# Altium schematic sheet [7]-FPGA-Power.SchDoc
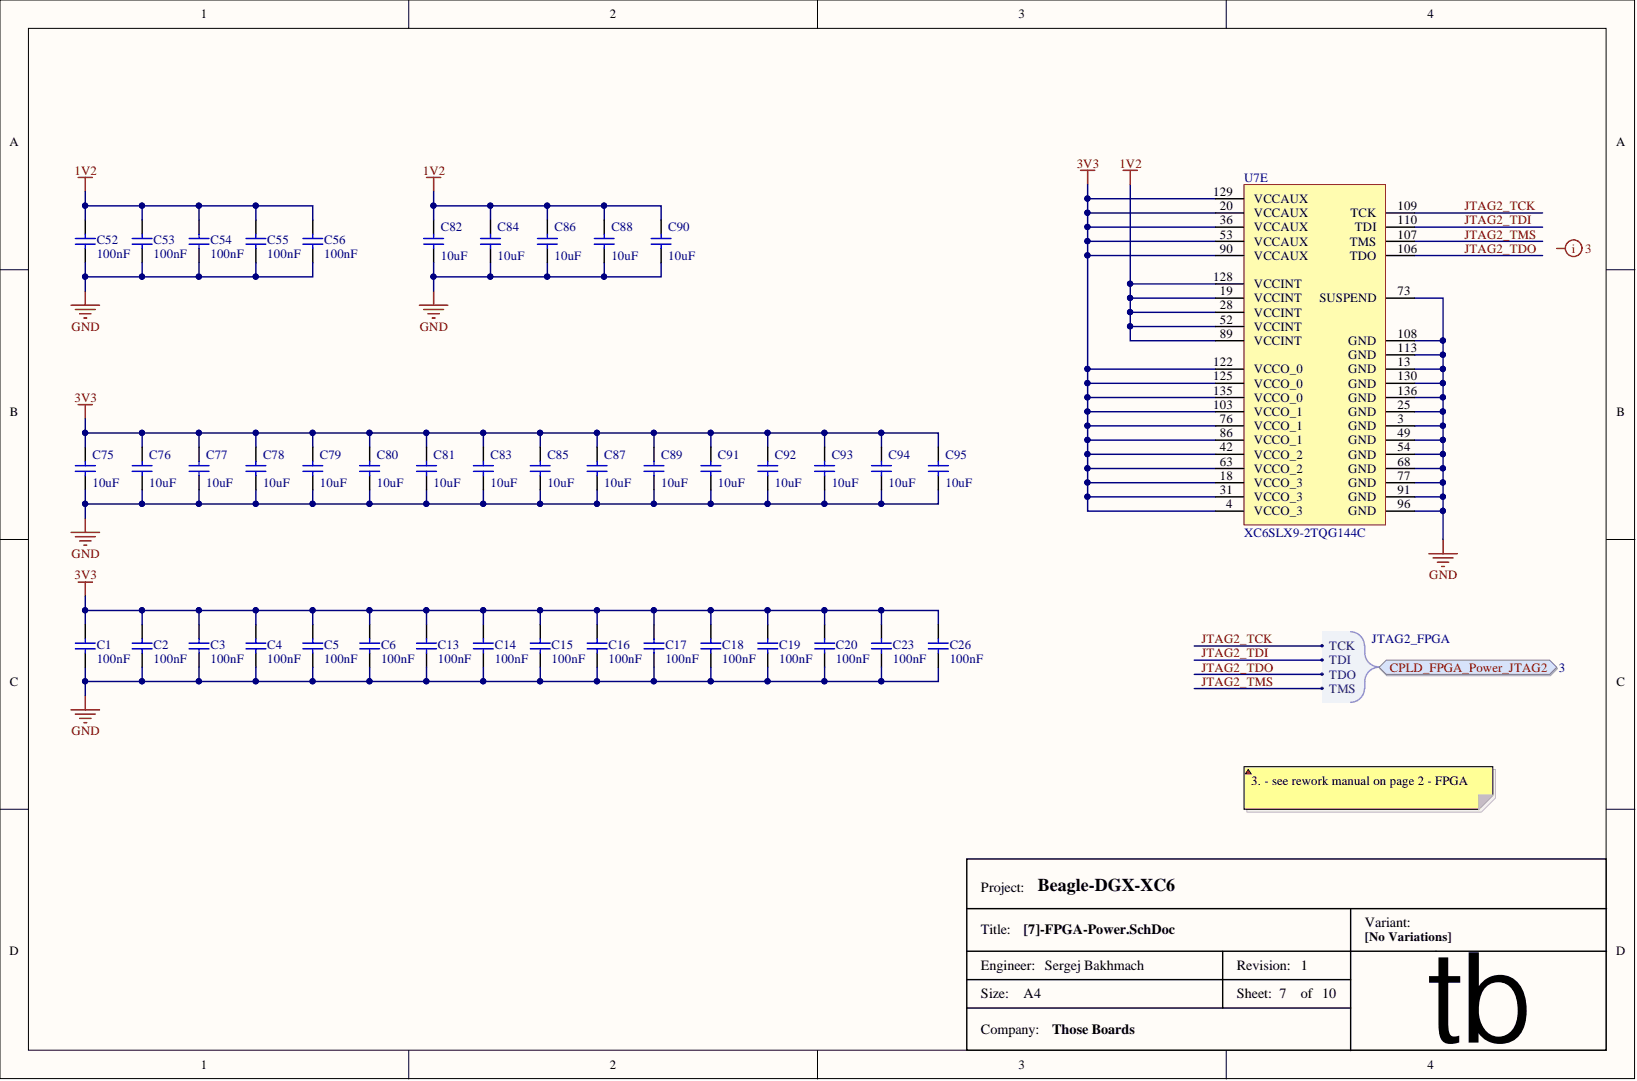
[diagram p0: [7]-FPGA-Power.SchDoc]

## Parts
C1  value 100nF  [C0603]  (hidden comment: CAP)
C2  value 100nF  [C0603]  (hidden comment: CAP)
C3  value 100nF  [C0603]  (hidden comment: CAP)
C4  value 100nF  [C0603]  (hidden comment: CAP)
C5  value 100nF  [C0603]  (hidden comment: CAP)
C6  value 100nF  [C0603]  (hidden comment: CAP)
C13  value 100nF  [C0603]  (hidden comment: CAP)
C14  value 100nF  [C0603]  (hidden comment: CAP)
C15  value 100nF  [C0603]  (hidden comment: CAP)
C16  value 100nF  [C0603]  (hidden comment: CAP)
C17  value 100nF  [C0603]  (hidden comment: CAP)
C18  value 100nF  [C0603]  (hidden comment: CAP)
C19  value 100nF  [C0603]  (hidden comment: CAP)
C20  value 100nF  [C0603]  (hidden comment: CAP)
C23  value 100nF  [C0603]  (hidden comment: CAP)
C26  value 100nF  [C0603]  (hidden comment: CAP)
C52  value 100nF  [C0603]  (hidden comment: CAP)
C53  value 100nF  [C0603]  (hidden comment: CAP)
C54  value 100nF  [C0603]  (hidden comment: CAP)
C55  value 100nF  [C0603]  (hidden comment: CAP)
C56  value 100nF  [C0603]  (hidden comment: CAP)
C75  value 10uF  [C0805]  (hidden comment: CAP)
C76  value 10uF  [C0805]  (hidden comment: CAP)
C77  value 10uF  [C0805]  (hidden comment: CAP)
C78  value 10uF  [C0805]  (hidden comment: CAP)
C79  value 10uF  [C0805]  (hidden comment: CAP)
C80  value 10uF  [C0805]  (hidden comment: CAP)
C81  value 10uF  [C0805]  (hidden comment: CAP)
C82  value 10uF  [C0805]  (hidden comment: CAP)
C83  value 10uF  [C0805]  (hidden comment: CAP)
C84  value 10uF  [C0805]  (hidden comment: CAP)
C85  value 10uF  [C0805]  (hidden comment: CAP)
C86  value 10uF  [C0805]  (hidden comment: CAP)
C87  value 10uF  [C0805]  (hidden comment: CAP)
C88  value 10uF  [C0805]  (hidden comment: CAP)
C89  value 10uF  [C0805]  (hidden comment: CAP)
C90  value 10uF  [C0805]  (hidden comment: CAP)
C91  value 10uF  [C0805]  (hidden comment: CAP)
C92  value 10uF  [C0805]  (hidden comment: CAP)
C93  value 10uF  [C0805]  (hidden comment: CAP)
C94  value 10uF  [C0805]  (hidden comment: CAP)
C95  value 10uF  [C0805]  (hidden comment: CAP)
U7  XC6SLX9-2TQG144C  [TQ144_N_Spartan6]  (part E)

## Ports
CPLD_FPGA_Power_JTAG2, CPLD_FPGA_Power_JTAG2.TCK, CPLD_FPGA_Power_JTAG2.TDI, CPLD_FPGA_Power_JTAG2.TDO, CPLD_FPGA_Power_JTAG2.TMS

## Nets
1V2: C52.2 C53.2 C54.2 C55.2 C56.2 C82.2 C84.2 C86.2 C88.2 C90.2 U7.19(VCCINT) U7.28(VCCINT) U7.52(VCCINT) U7.89(VCCINT) U7.128(VCCINT)
3V3: C1.2 C2.2 C3.2 C4.2 C5.2 C6.2 C13.2 C14.2 C15.2 C16.2 C17.2 C18.2 C19.2 C20.2 C23.2 C26.2 C75.2 C76.2 C77.2 C78.2 C79.2 C80.2 C81.2 C83.2 C85.2 C87.2 C89.2 C91.2 C92.2 C93.2 C94.2 C95.2 U7.4(VCCO_3) U7.18(VCCO_3) U7.20(VCCAUX) U7.31(VCCO_3) U7.36(VCCAUX) U7.42(VCCO_2) U7.53(VCCAUX) U7.63(VCCO_2) U7.76(VCCO_1) U7.86(VCCO_1) U7.90(VCCAUX) U7.103(VCCO_1) U7.122(VCCO_0) U7.125(VCCO_0) U7.129(VCCAUX) U7.135(VCCO_0)
GND: C1.1 C2.1 C3.1 C4.1 C5.1 C6.1 C13.1 C14.1 C15.1 C16.1 C17.1 C18.1 C19.1 C20.1 C23.1 C26.1 C52.1 C53.1 C54.1 C55.1 C56.1 C75.1 C76.1 C77.1 C78.1 C79.1 C80.1 C81.1 C82.1 C83.1 C84.1 C85.1 C86.1 C87.1 C88.1 C89.1 C90.1 C91.1 C92.1 C93.1 C94.1 C95.1 U7.3(GND) U7.13(GND) U7.25(GND) U7.49(GND) U7.54(GND) U7.68(GND) U7.73(SUSPEND) U7.77(GND) U7.91(GND) U7.96(GND) U7.108(GND) U7.113(GND) U7.130(GND) U7.136(GND)
JTAG2_TCK: U7.109(TCK) ; port CPLD_FPGA_Power_JTAG2
JTAG2_TDI: U7.110(TDI) ; port CPLD_FPGA_Power_JTAG2
JTAG2_TDO: U7.106(TDO) ; port CPLD_FPGA_Power_JTAG2
JTAG2_TMS: U7.107(TMS) ; port CPLD_FPGA_Power_JTAG2
N00442:

## Library data of the parts
C1-C6 C13-C20 C23 C26 C52-C56 = CAP: Manufacturer: Vishay / Vitramon; Manufacturer Part: VJ0603Y104JXJPW1BC; Type of Component: Ceramic Capacitor; Component Kind: Standard; Manufacturer Link: http://www.vishay.com/product?docid=28548; Package / Case: 0603; Supplier: mouser; Supplier Link: http://www.mouser.com/ProductDetail/Vishay/VJ0603Y104JXJPW1BC/?qs=%2fha2pyFaduidGoO0X7aK7Xgs%2fjxfhnLiK6SRw4Lz0%252bZK3PJ8DddTrQ%3d%3d; Supplier Part: 77-VJ0603Y104JXJPBC; Library Name: RPI-VITA.IntLib
C75-C95 = CAP: Manufacturer: Murata; Manufacturer Part: GRM21BC81C106KE15L; Type of Component: Ceramic Capacitor; Component Kind: Standard; Manufacturer Link: http://psearch.en.murata.com/capacitor/result/smd/?status=all&pid=GRM21BC81C106KE15L; Package / Case: 0805; Supplier: mouser; Supplier Link: http://www.mouser.com/ProductDetail/Murata/GRM21BC81C106KE15L/?qs=%2fha2pyFadugq0yC%2fv5omHdWffW%252bX1z%252bEjQXmyIECJNTTTKySHodREy42G6WZAHxu; Supplier Part: 81-GRM21BC81C106KE5L; Library Name: RPI-VITA.IntLib
U7 = XC6SLX9-2TQG144C: Manufacturer: Xilinx; Type of Component: FPGA; Component Kind: Standard; Manufacturer Part: XC6SLX9-2TQG144C; Package / Case: TQG-144; Supplier 1: Hk-Ada; Supplier 1 Part: XC6SLX9-2TQG144C; Value: XC6SLX9-2TQG144C; Library Name: RPI-VITA.IntLib
